# Altium schematic sheet stm32core2.SchDoc
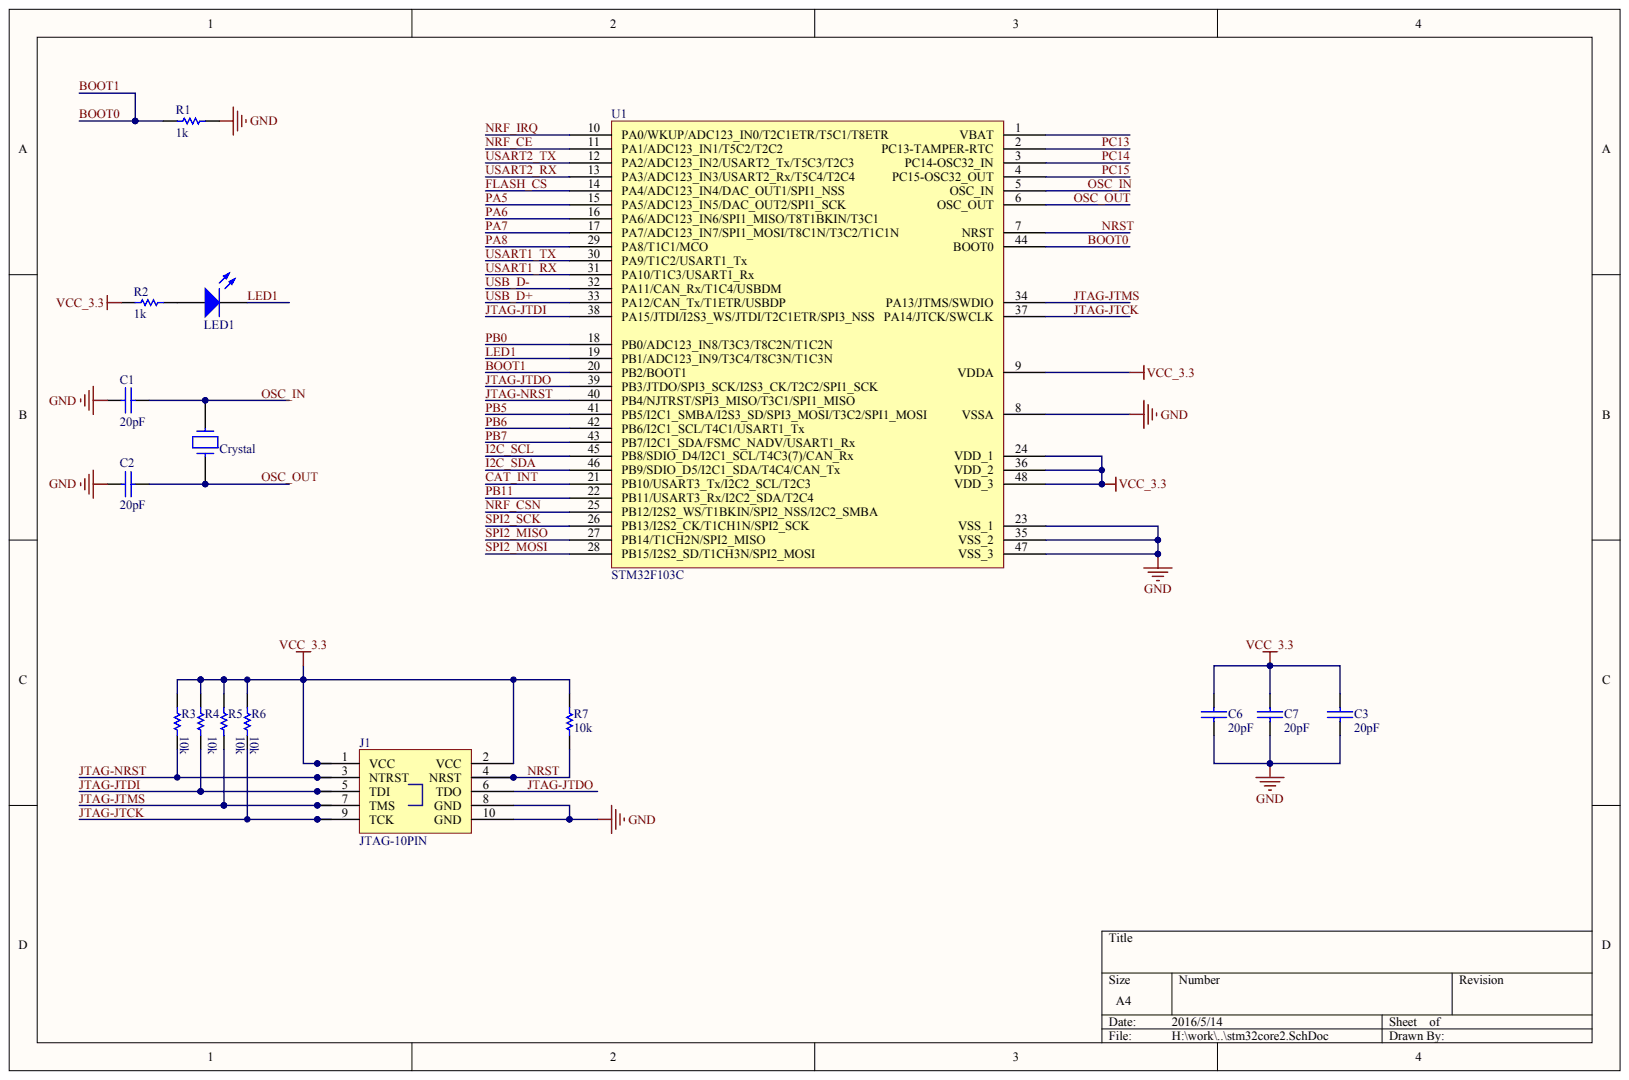
[diagram p0: stm32core2.SchDoc]

## Parts
1  Crystal
C1  20pF
C2  20pF
C3  20pF
C6  20pF
C7  20pF
J1  JTAG-10PIN
LED1  LED
R1  1k
R2  1k
R3  10k
R4  10k
R5  10k
R6  10k
R7  10k
U1  STM32F103C

## Nets
GND: C1.1 C2.1 C3.2 C6.2 C7.2 J1.8(GND) J1.10(GND) R1.2 U1.8(VSSA) U1.23(VSS_1) U1.35(VSS_2) U1.47(VSS_3)
VCC_3.3: C3.1 C6.1 C7.1 J1.1(VCC) J1.2(VCC) R2.1 R3.2 R4.2 R5.2 R6.2 R7.1 U1.9(VDDA) U1.24(VDD_1) U1.36(VDD_2) U1.48(VDD_3)
BOOT0: R1.1 U1.20(PB2/BOOT1) U1.44(BOOT0)
CAT_INT: U1.21(PB10/USART3_Tx/I2C2_SCL/T2C3)
FLASH_CS: U1.14(PA4/ADC123_IN4/DAC_OUT1/SPI1_NSS)
I2C_SCL: U1.45(PB8/SDIO_D4/I2C1_SCL/T4C3(7)/CAN_Rx)
I2C_SDA: U1.46(PB9/SDIO_D5/I2C1_SDA/T4C4/CAN_Tx)
JTAG-JTCK: J1.9(TCK) R6.1 U1.37(PA14/JTCK/SWCLK)
JTAG-JTDI: J1.5(TDI) R4.1 U1.38(PA15/JTDI/I2S3_WS/JTDI/T2C1ETR/SPI3_NSS)
JTAG-JTDO: J1.6(TDO) U1.39(PB3/JTDO/SPI3_SCK/I2S3_CK/T2C2/SPI1_SCK)
JTAG-JTMS: J1.7(TMS) R5.1 U1.34(PA13/JTMS/SWDIO)
JTAG-NRST: J1.3(NTRST) R3.1 U1.40(PB4/NJTRST/SPI3_MISO/T3C1/SPI1_MISO)
LED1: LED1.2 U1.19(PB1/ADC123_IN9/T3C4/T8C3N/T1C3N)
NRF_CE: U1.11(PA1/ADC123_IN1/T5C2/T2C2)
NRF_CSN: U1.25(PB12/I2S2_WS/T1BKIN/SPI2_NSS/I2C2_SMBA)
NRF_IRQ: U1.10(PA0/WKUP/ADC123_IN0/T2C1ETR/T5C1/T8ETR)
NRST: J1.4(NRST) R7.2 U1.7(NRST)
OSC_IN: 1.2 C1.2 U1.5(OSC_IN)
OSC_OUT: 1.1 C2.2 U1.6(OSC_OUT)
PA5: U1.15(PA5/ADC123_IN5/DAC_OUT2/SPI1_SCK)
PA6: U1.16(PA6/ADC123_IN6/SPI1_MISO/T8T1BKIN/T3C1)
PA7: U1.17(PA7/ADC123_IN7/SPI1_MOSI/T8C1N/T3C2/T1C1N)
PA8: U1.29(PA8/T1C1/MCO)
PB0: U1.18(PB0/ADC123_IN8/T3C3/T8C2N/T1C2N)
PB5: U1.41(PB5/I2C1_SMBA/I2S3_SD/SPI3_MOSI/T3C2/SPI1_MOSI)
PB6: U1.42(PB6/I2C1_SCL/T4C1/USART1_Tx)
PB7: U1.43(PB7/I2C1_SDA/FSMC_NADV/USART1_Rx)
PB11: U1.22(PB11/USART3_Rx/I2C2_SDA/T2C4)
PC13: U1.2(PC13-TAMPER-RTC)
PC14: U1.3(PC14-OSC32_IN)
PC15: U1.4(PC15-OSC32_OUT)
SPI2_MISO: U1.27(PB14/T1CH2N/SPI2_MISO)
SPI2_MOSI: U1.28(PB15/I2S2_SD/T1CH3N/SPI2_MOSI)
SPI2_SCK: U1.26(PB13/I2S2_CK/T1CH1N/SPI2_SCK)
USART1_RX: U1.31(PA10/T1C3/USART1_Rx)
USART1_TX: U1.30(PA9/T1C2/USART1_Tx)
USART2_RX: U1.13(PA3/ADC123_IN3/USART2_Rx/T5C4/T2C4)
USART2_TX: U1.12(PA2/ADC123_IN2/USART2_Tx/T5C3/T2C3)
USB_D+: U1.33(PA12/CAN_Tx/T1ETR/USBDP)
USB_D-: U1.32(PA11/CAN_Rx/T1C4/USBDM)
NetLED1_1: LED1.1 R2.2

Not connected: U1 1(VBAT)
